# Altium schematic sheet RX.SchDoc
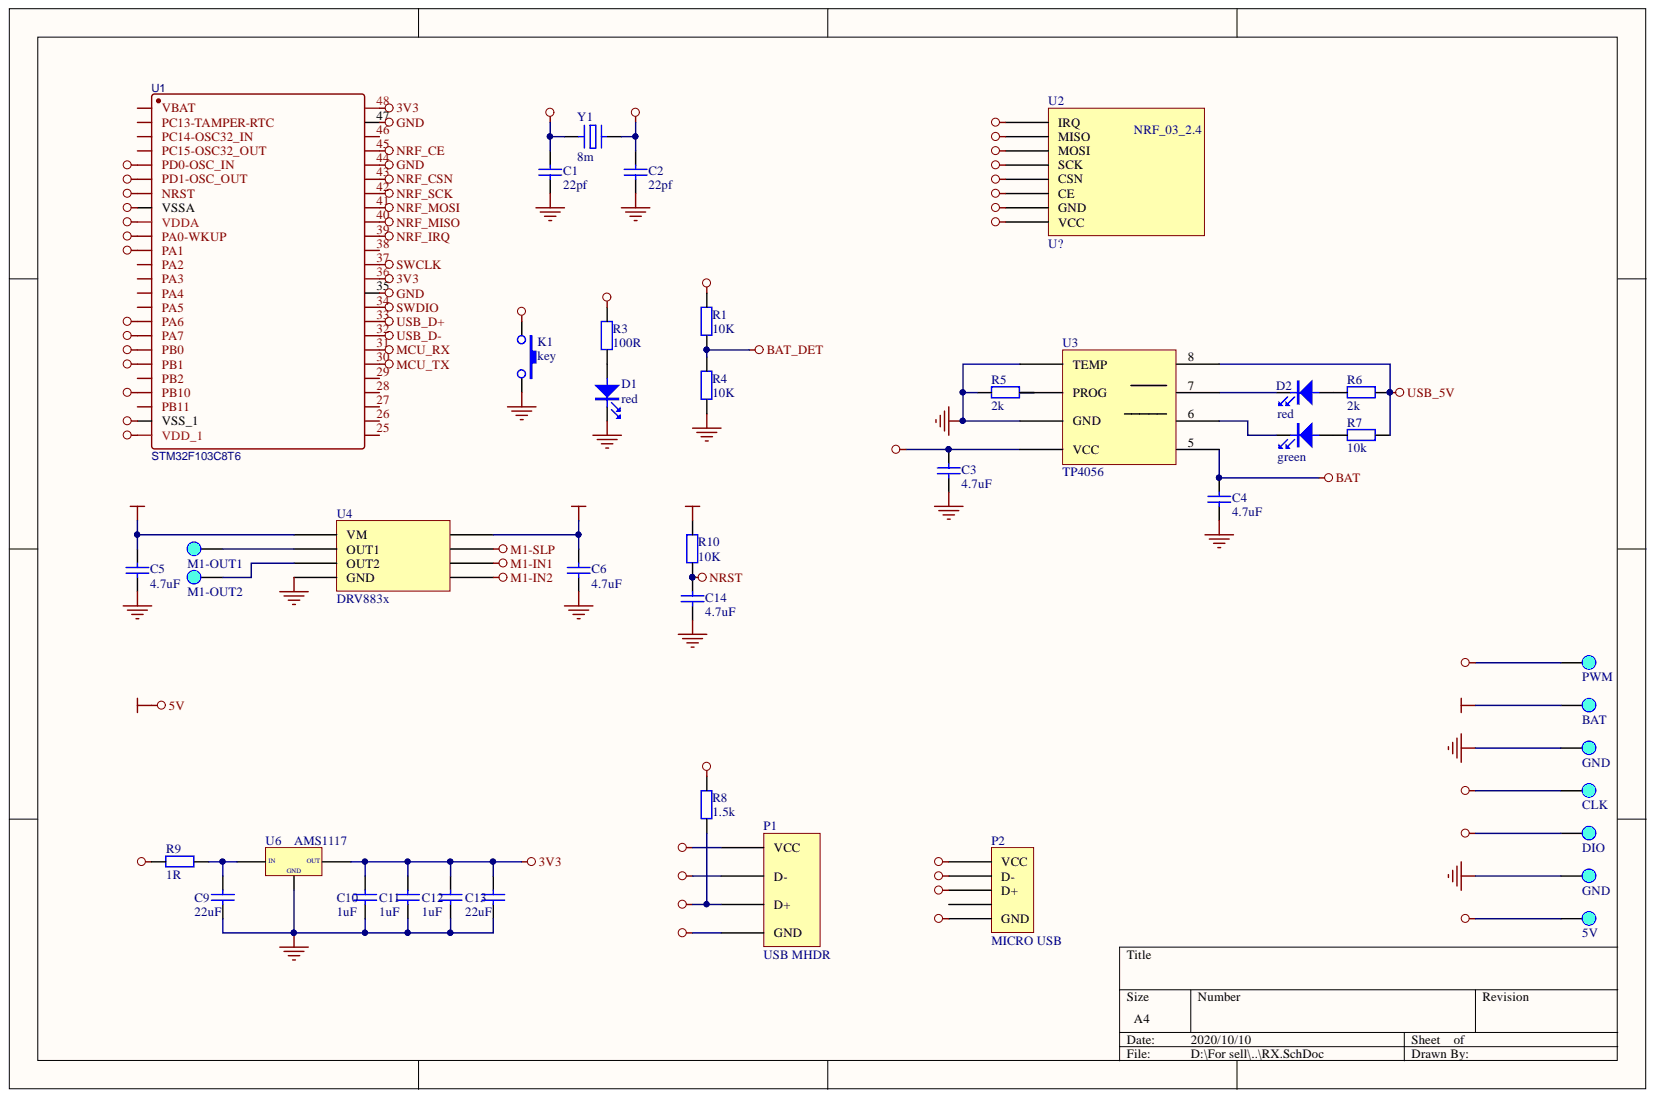
[diagram p0: RX.SchDoc]

## Parts
5V  5V  [Pad_B]
BAT  BAT  [Pad_B]
C1  22pf  [电容_C0603]
C2  22pf  [电容_C0603]
C3  4.7uF  [电容_C0603]
C4  4.7uF  [电容_C0603]
C5  4.7uF  [电容_C0603]
C6  4.7uF  [电容_C0603]
C9  22uF  [电容_C0603]
C10  1uF  [电容_C0603]
C11  1uF  [电容_C0603]
C12  1uF  [电容_C0603]
C13  22uF  [电容_C0603]
C14  4.7uF  [电容_C0603]
CLK  CLK  [Pad_B]
D1  red  [LED_0603]
D2  red  [LED_0603]
D3  green  [LED_0603]
DIO  DIO  [Pad_B]
GND  GND  [Pad_B]
GND1  GND  [Pad_B]
K1  key  [开关按键_SKTH]
M1  M1-OUT1  [Pad_B]
M2  M1-OUT2  [Pad_B]
P1  USB MHDR  [接插件_USB 公头 90°]
P2  MICRO USB  [接插件_MICRO 牛角插座 USB 卷边]
PWM  PWM  [Pad_B]
R1  10K  [电阻_R0603]
R3  100R  [电阻_R0603]
R4  10K  [电阻_R0603]
R5  2k  [电阻_R0603]
R6  2k  [电阻_R0603]
R7  2k  R: 10k  [电阻_R0603]
R8  1.5k  [电阻_R0603]
R9  1R  [电阻_R0603]
R10  10K  [电阻_R0603]
U1  STM32F103C8T6  [STM32F103C8T6]
U2  U?  [NRF-1]
U3  TP4056  [SOP-8_PAD]
U4  DRV883x  [WSON-8]
U6  AMS1117  [稳压芯片_SOT-89]
Y1  F: 8m  [晶振_5032]  (hidden comment: XTAL)

## Nets
3V3: C6.2 C10.2 C11.2 C12.2 C13.2 R10.2 U1.9(VDDA) U1.24(VDD_1) U1.36 U1.48 U2.1(VCC) U4.8 U6.2
5V: 5V.1 BAT.1 C4.2 C5.1 P1.1(VCC) R1.2 R8.2 R9.2 U3.5 U4.1(VM)
BAT_DET: R1.1 R4.2 U1.11(PA1)
GND: C1.1 C2.1 C3.1 C4.1 C5.2 C6.1 C9.1 C10.1 C11.1 C12.1 C13.1 C14.1 D1.K GND.1 GND1.1 K1.2 P1.0 P1.4(GND) P2.0 P2.5(GND) R4.1 R5.1 U1.8(VSSA) U1.23(VSS_1) U1.35 U1.44 U1.47 U2.2(GND) U3.0 U3.1(TEMP) U3.3(GND) U4.4(GND) U6.1
KEY: K1.1 U1.21(PB10)
LED: R3.2 U1.10(PA0-WKUP)
M1-IN1: U1.18(PB0) U4.6
M1-IN2: U1.19(PB1) U4.5
M1-SLP: U1.17(PA7) U4.7
MCU_RX: P2.2(D-) U1.31
MCU_TX: P2.3(D+) U1.30
NRF_CE: U1.45 U2.3(CE)
NRF_CSN: U1.43 U2.4(CSN)
NRF_IRQ: U1.39 U2.8(IRQ)
NRF_MISO: U1.40 U2.7(MISO)
NRF_MOSI: U1.41 U2.6(MOSI)
NRF_SCK: U1.42 U2.5(SCK)
NRST: C14.2 R10.1 U1.7(NRST)
OSC_IN: C1.2 U1.5(PD0-OSC_IN) Y1.1
OSC_OUT: C2.2 U1.6(PD1-OSC_OUT) Y1.2
PWM: PWM.1 U1.16(PA6)
SWCLK: CLK.1 U1.37
SWDIO: DIO.1 U1.34
USB_5V: C3.2 P2.1(VCC) R6.2 R7.2 U3.4(VCC) U3.8
USB_D+: P1.3(D+) R8.1 U1.33
USB_D-: P1.2(D-) U1.32
NetC9_2: C9.2 R9.1 U6.3
NetD1_A: D1.A R3.1
NetD2_A: D2.A R6.1
NetD2_K: D2.K U3.7
NetD3_A: D3.A R7.1
NetD3_K: D3.K U3.6
NetM1_1: M1.1 U4.2(OUT1)
NetM2_1: M2.1 U4.3(OUT2)
NetR5_2: R5.2 U3.2(PROG)

Not connected: P2 4; U1 1(VBAT) 2(PC13-TAMPER-RTC) 3(PC14-OSC32_IN) 4(PC15-OSC32_OUT) 12(PA2) 13(PA3) 14(PA4) 15(PA5) 20(PB2) 22(PB11) 25 26 27 28 29 38 46

## Library data of the parts
5V = Pad: _Comma: ,; _Funcyusa: Test; _Specification: Pad,Test,5V; _Name: Pad; _Remarks: 5V; Component Kind: Standard; Library Name: 元件库.IntLib
BAT = Pad: _Comma: ,; _Funcyusa: Test; _Specification: Pad,Test,BAT; _Name: Pad; _Remarks: BAT; Component Kind: Standard; Library Name: 元件库.IntLib
C1-C6 C9-C14 = cap: U: 50V; 参数: Cap,0805,X7R,104K,50V,125C,±10%; Component Kind: Standard
  C1 C2: C: 22pf; Library Name: WatchX.SCHLIB
  C3-C6 C14: C: 4.7uF; Library Name: WatchX.SCHLIB
  C9 C13: C: 22uF; Library Name: 元件库.IntLib
  C10-C12: C: 1uF; Library Name: 元件库.IntLib
CLK = Pad: _Comma: ,; _Funcyusa: Test; _Specification: Pad,Test,CLK; _Name: Pad; _Remarks: CLK; Component Kind: Standard; Library Name: 元件库.IntLib
D1-D3 = LED: 参数: LED,0603,Red; Component Kind: Standard; Library Name: WatchX.SCHLIB
DIO = Pad: _Comma: ,; _Funcyusa: Test; _Specification: Pad,Test,DIO; _Name: Pad; _Remarks: DIO; Component Kind: Standard; Library Name: 元件库.IntLib
GND GND1 = Pad: _Comma: ,; _Funcyusa: Test; _Specification: Pad,Test,GND; _Name: Pad; _Remarks: GND; Component Kind: Standard; Library Name: 元件库.IntLib
K1 = SW-PB_1: 参数: Key,TS-018A 2*4全包无柱带支架 力度250N; 备注: ADD; Component Kind: Standard; Library Name: WatchX.SCHLIB
M1 = Pad: _Comma: ,; _Funcyusa: Test; _Specification: Pad,Test,M1-OUT1; _Name: Pad; _Remarks: M1-OUT1; Component Kind: Standard; Library Name: 元件库.IntLib
M2 = Pad: _Comma: ,; _Funcyusa: Test; _Specification: Pad,Test,M1-OUT2; _Name: Pad; _Remarks: M1-OUT2; Component Kind: Standard; Library Name: 元件库.IntLib
P2 = MICRO USB 5PIN: _Comma: ,; _Name: Port; _Specification: Port,MICRO USB,5PIN; _Value: 5PIN; _Model: MICRO USB; Component Kind: Standard; Library Name: WatchX.SCHLIB
PWM = Pad: _Comma: ,; _Funcyusa: Test; _Specification: Pad,Test,PWM; _Name: Pad; _Remarks: PWM; Component Kind: Standard; Library Name: 元件库.IntLib
R1 R3-R10 = Res: P: 1/8W; 参数: Res,0805,0R,±1%,1/8W,100ppm,155C; Component Kind: Standard
  R1 R4 R10: Library Name: 元件库.IntLib; R: 10K
  R3: Library Name: WatchX.SCHLIB; R: 100R
  R5 R6: Library Name: WatchX.SCHLIB; R: 2k
  R7: Library Name: WatchX.SCHLIB
  R8: Library Name: WatchX.SCHLIB; R: 1.5k
  R9: Library Name: 元件库.IntLib; R: 1R
U1 = STM32F103C8T6: BOM_Manufacturer: STMicroelectronics; SMT Type: Basic; BOM_LCSC Assembly: Yes; BOM_Manufacturer Part: STM32F103C8T6; BOM_Supplier: LCSC; BOM_Supplier Part: C8734; Component Kind: Standard; image: //image.lceda.cn/szlcsc/C8734.jpg; Library Name: WatchX.SCHLIB; spicePre: U; spiceSymbolName: STM32F103C8T6; timeStamp: 1455028086
U2 = NRF: NRF24L01; Component Kind: Standard; Library Name: WatchX.SCHLIB
U3 = TP4056: 参数: Charge Control,LP4056,ESOP-8; Component Kind: Standard; Library Name: WatchX.SCHLIB
P1 = USB MHDR: Component Kind: Standard; Library Name: WatchX.SCHLIB
U4 = DRV883x: Component Kind: Standard; Description: DRV883x; Library Name: xSchlib.SchLib
U6 = AMS1117: Component Kind: Standard; Library Name: 元件库.IntLib
Y1 = XTAL: Component Kind: Standard; Library Name: WatchX.SCHLIB
